# Altium schematic sheet USB C Port.SchDoc
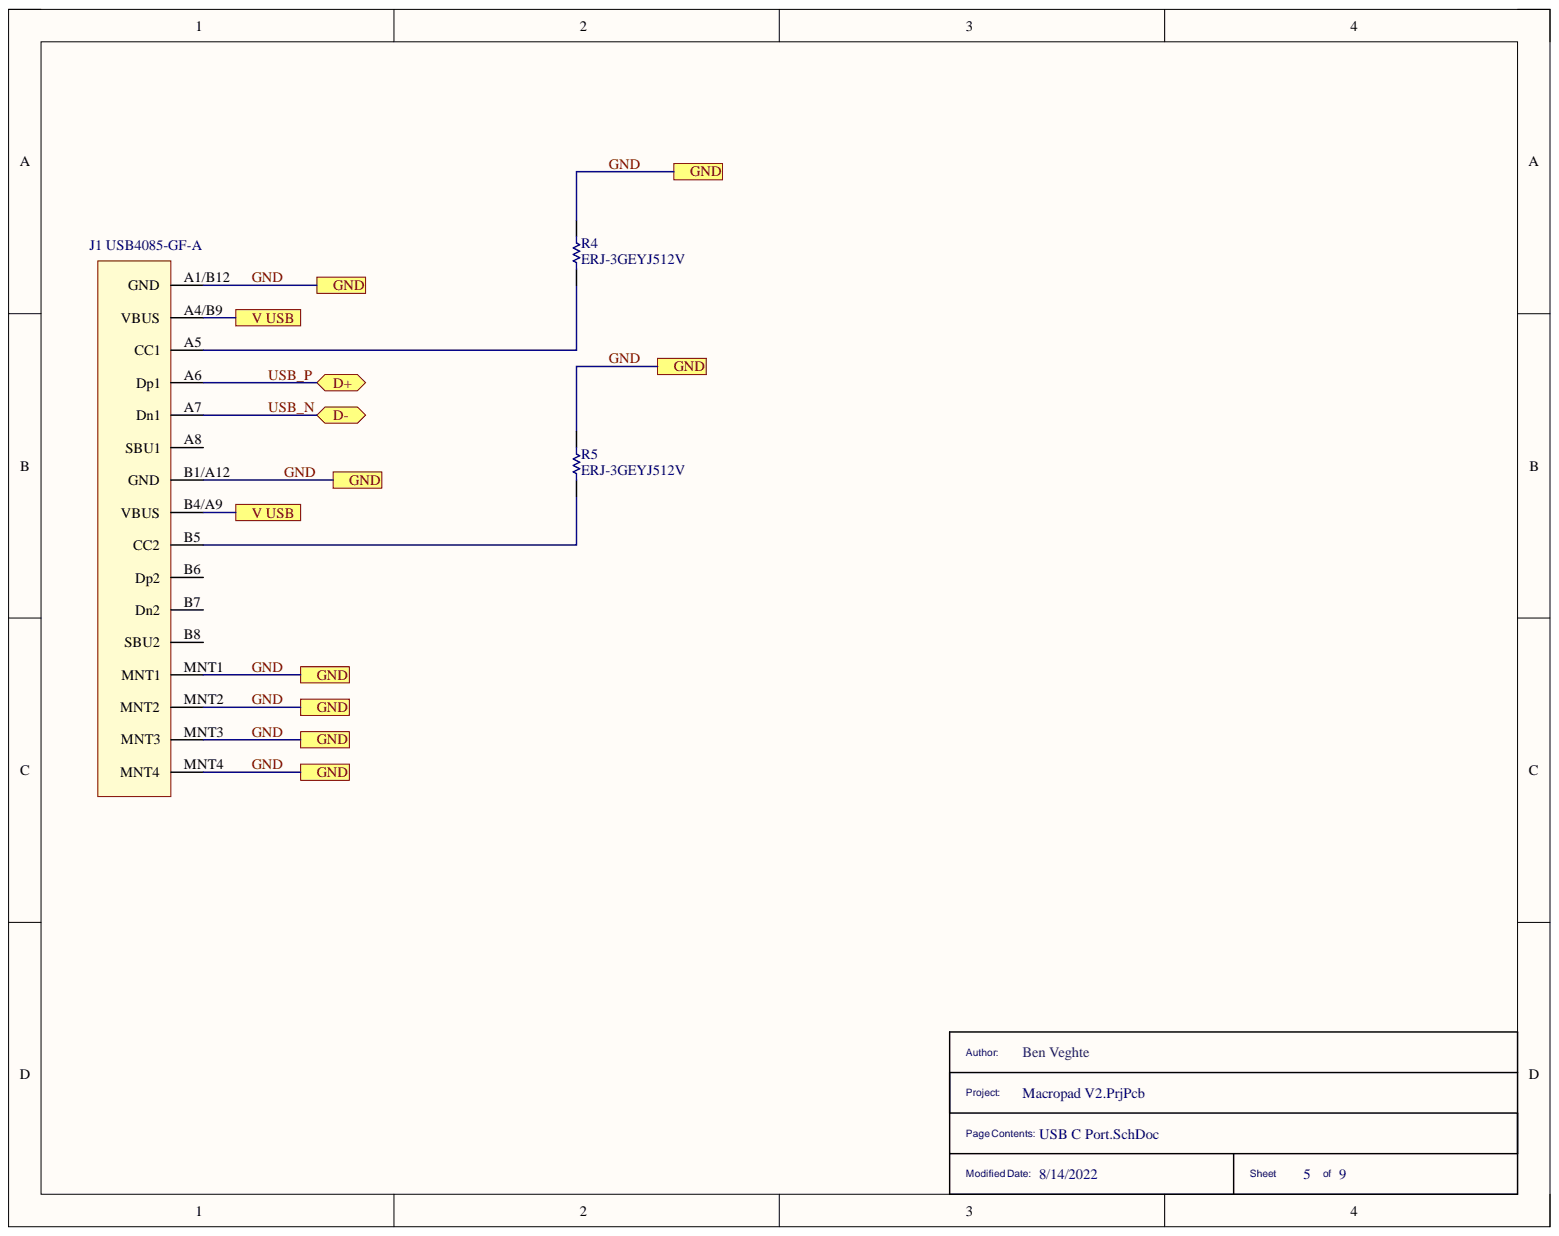
[diagram p0: USB C Port.SchDoc]

## Parts
J1  USB4085-GF-A  [USB4105-GF-A-120]
R4  ERJ-3GEYJ512V  [RESC1608X55X30LL15T15]
R5  ERJ-3GEYJ512V  [RESC1608X55X30LL15T15]

## Ports
D+, D-, GND, V USB

## Nets
GND: J1.A1/B12(GND) J1.B1/A12(GND) J1.MNT1 J1.MNT2 J1.MNT3 J1.MNT4 R4.2 R5.2 ; port GND
USB_N: J1.A7(Dn1)
USB_P: J1.A6(Dp1)
V USB: J1.A4/B9(VBUS) J1.B4/A9(VBUS) ; port V USB
NetJ1_A5: J1.A5(CC1) R4.1
NetJ1_B5: J1.B5(CC2) R5.1

Not connected: J1 A8(SBU1) B6(Dp2) B7(Dn2) B8(SBU2)

## Library data of the parts
J1 = USB4085-GF-A: Conn USB 2.0 Type C RCP 16 POS 0.85mm Solder RA Thru-Hole 16 Terminal 1 Port T/R; Manufacturer: Global Connector Technology; Gender: Receptacle; Number of Positions: 16; USB Standard: USB 2.0; Category: USB Connectors; Component Kind: Standard; ComponentLink1Description: https://datasheet.ciiva.com/71788/usb4085-71788147.pdf?src-supplier=Digi-Key; ComponentLink1URL: https://datasheet.ciiva.com/71788/usb4085-71788147.pdf?src-supplier=Digi-Key; ComponentLink2Description: https://datasheet.ciiva.com/71788/2674755-71788146.pdf?src-supplier=Element14; ComponentLink2URL: https://datasheet.ciiva.com/71788/2674755-71788146.pdf?src-supplier=Element14; Contact Material: Copper Alloy; Contact Plating: Gold; Manufacturer Part Number: USB4085-GF-A; Orientation: Right Angle; Pricing 1: 1=0.95500, 10=0.85100, 25=0.79600, 50=0.73300, 100=0.63100, 250=0.57900, 500=0.53500 (USD); RoHS: Yes; Stock 1: 3052; Supplier 1: Newark; Library Name: Universal Parts.IntLib
R4 R5 = CMP-2000-00803-1: Manufacturer: Panasonic; Component Kind: Standard; Library Name: Altium Content Vault; Part Number: ERJ-3GEYJ512V
